# Altium schematic sheet IO.SchDoc
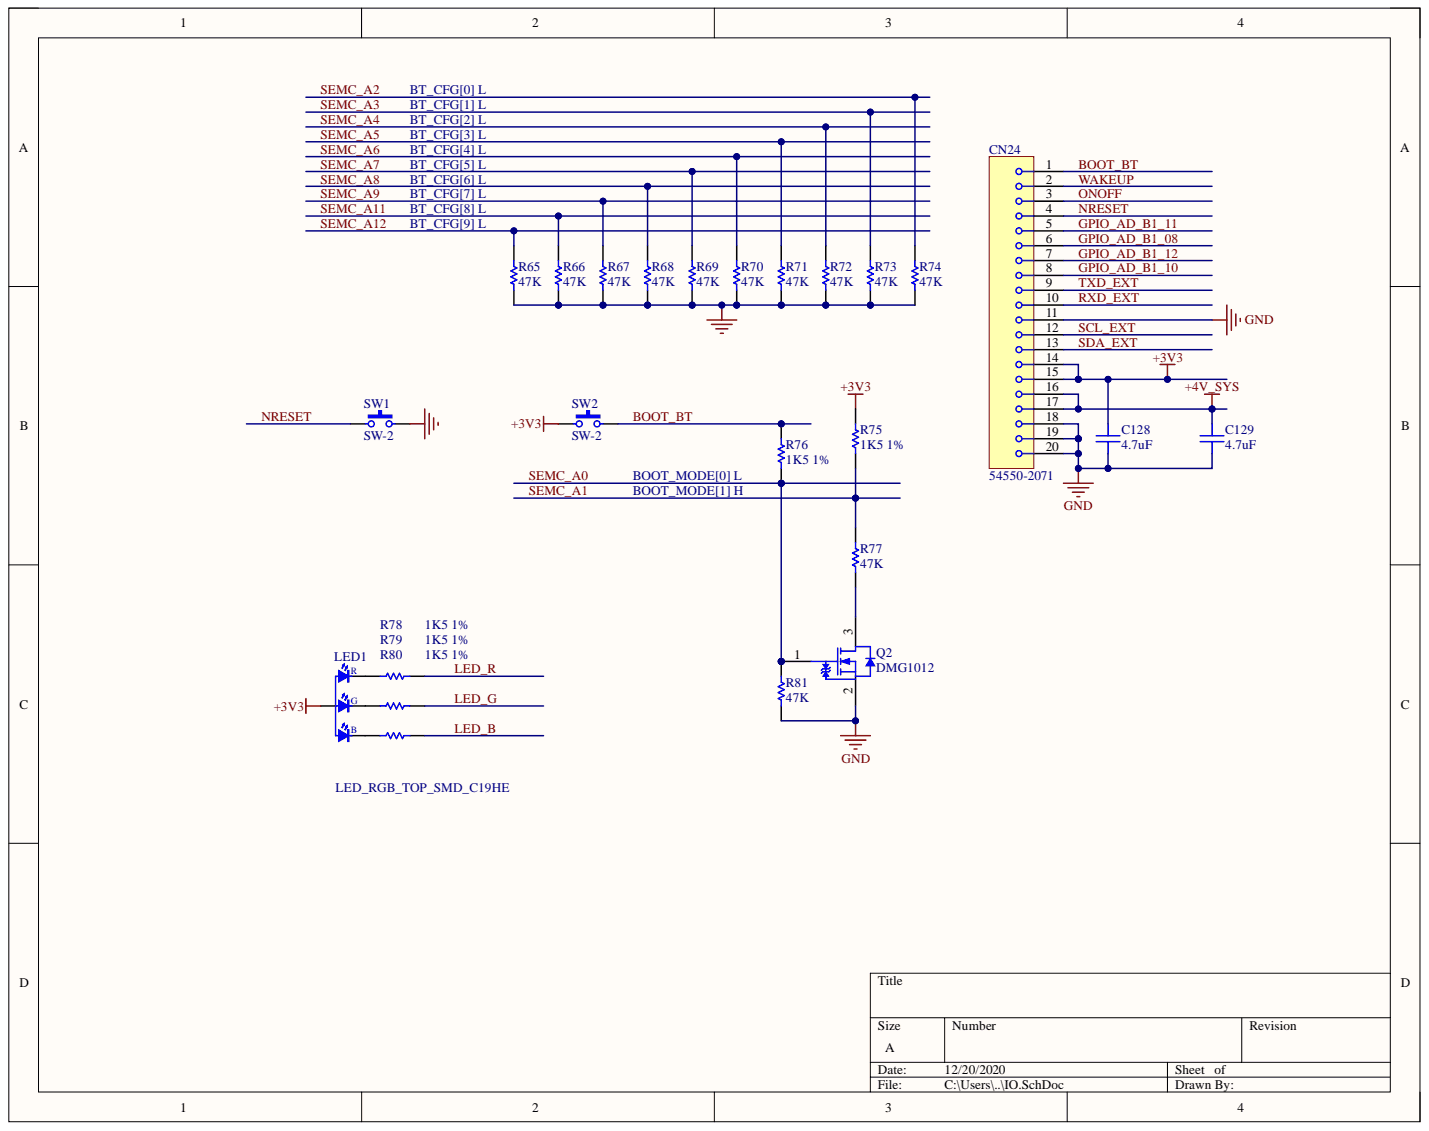
[diagram p0: IO.SchDoc]

## Parts
C128  4.7uF  [AA-0402]
C129  4.7uF  [AA-0402]
CN24  54550-2071  [54550-2071]
LED1  LED_RGB_TOP_SMD_C19HE  [LTST-C19HE1WT]
Q2  DMG1012  [SOT-523]
R65  47K  [AA-0402]
R66  47K  [AA-0402]
R67  47K  [AA-0402]
R68  47K  [AA-0402]
R69  47K  [AA-0402]
R70  47K  [AA-0402]
R71  47K  [AA-0402]
R72  47K  [AA-0402]
R73  47K  [AA-0402]
R74  47K  [AA-0402]
R75  1K5 1%  [AA-0402]
R76  1K5 1%  [AA-0402]
R77  47K  [AA-0402]
R78  1K5 1%  [AA-0402]
R79  1K5 1%  [AA-0402]
R80  1K5 1%  [AA-0402]
R81  47K  [AA-0402]
SW1  SW-2  [LY_A03_10]
SW2  SW-2  [LY_A03_10]

## Nets
+3V3: C128.2 CN24.14 CN24.15 LED1.4 R75.2 SW2.1
+4V_SYS: C129.2 CN24.16 CN24.17
GND: C128.1 C129.1 CN24.11 CN24.18 CN24.19 CN24.20 Q2.2 R65.1 R66.1 R67.1 R68.1 R69.1 R70.1 R71.1 R72.1 R73.1 R74.1 R81.1 SW1.2
BOOT_BT: CN24.1 R76.1 SW2.2
GPIO_AD_B1_08: CN24.6
GPIO_AD_B1_10: CN24.8
GPIO_AD_B1_11: CN24.5
GPIO_AD_B1_12: CN24.7
LED_B: R80.1
LED_G: R79.1
LED_R: R78.1
NRESET: CN24.4 SW1.1
ONOFF: CN24.3
RXD_EXT: CN24.10
SCL_EXT: CN24.12
SDA_EXT: CN24.13
SEMC_A0: Q2.1 R76.2 R81.2
SEMC_A1: R75.1 R77.2
SEMC_A2: R74.2
SEMC_A3: R73.2
SEMC_A4: R72.2
SEMC_A5: R71.2
SEMC_A6: R70.2
SEMC_A7: R69.2
SEMC_A8: R68.2
SEMC_A9: R67.2
SEMC_A11: R66.2
SEMC_A12: R65.2
TXD_EXT: CN24.9
WAKEUP: CN24.2
NetLED1_1: LED1.1 R78.2
NetLED1_2: LED1.2 R79.2
NetLED1_3: LED1.3 R80.2
NetQ2_3: Q2.3 R77.1

## Library data of the parts
C128 C129 = 00005453: Database Table Name: Capacitor; Component Kind: Standard; Library Name: ALT_DB.DBLIB; Value: 4.7uF
CN24 = header_20PIN_1_ROW: Component Kind: Standard; Library Name: ULTIMA_LIB.SCHLIB
Q2 = 40041020G: Small-Signal MOSFET,N-chan. 20V, SOT-523, RDSon=0,4Ohm, 0.63A, -55+150(TJ); Manufacturer: Diodes Incorporated; Manufacturer Part Number: DMG1012T-7; Allegro PCB Footprint: SOT523; COMPHEIGHT: 0.8; Database Table Name: Transistors; Komentaras: $; Rating: 0.28W; Value: DMG1012T; ActivepartsID: https://www.diodes.com/assets/Datasheets/ds31783.pdf; Availability: In Stock; Component Kind: Standard; Data: 9/26/2017; Distributor: Digi-Key; Distributor Part Number: DMG1012T-7DITR-ND; Library Name: ALT_DB.DBLIB; Package: 3000T&R; Part Number: 40041020G; Part Type: Transistors\FET_N; Price: 0.05566; SMD: Yes; Datasheet: E:\pdf\tranzistoriai\ds31783.PDF
R65-R74 R77 R81 = 10012076Wa: Database Table Name: Resistor; Component Kind: Standard; Library Name: ALT_DB.DBLIB; Signal Integrity: Res; Simulation: RESISTOR; Value: 47K
R75 R76 R78-R80 = Res1: Manufacturer: Panasonic; Component Kind: Standard; Signal Integrity: Res; Simulation: RESISTOR; Value: 1K5 1%; Library Name: ULTIMA_LIB.SCHLIB
LED1 = LED_RGB_TOP_SMD_C19HE: Component Kind: Standard; Library Name: ULTIMA_LIB.SCHLIB
SW1 SW2 = SW-2: Component Kind: Standard; Library Name: ULTIMA_LIB.SCHLIB
